# Altium schematic sheet Power section.SchDoc
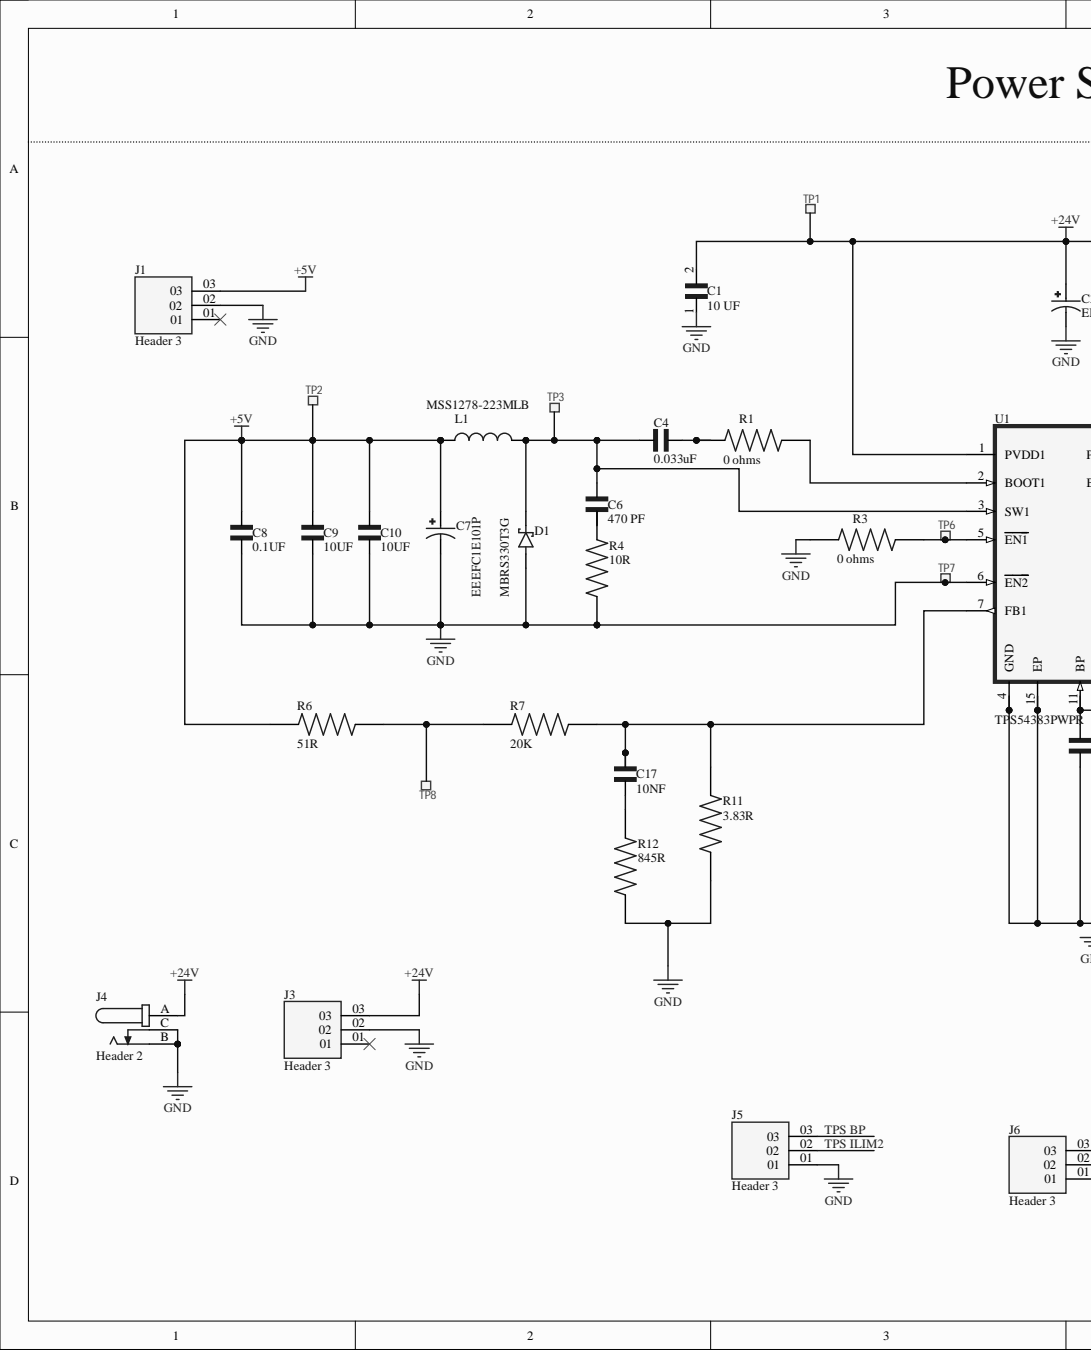
[diagram p0: Power section.SchDoc, left]
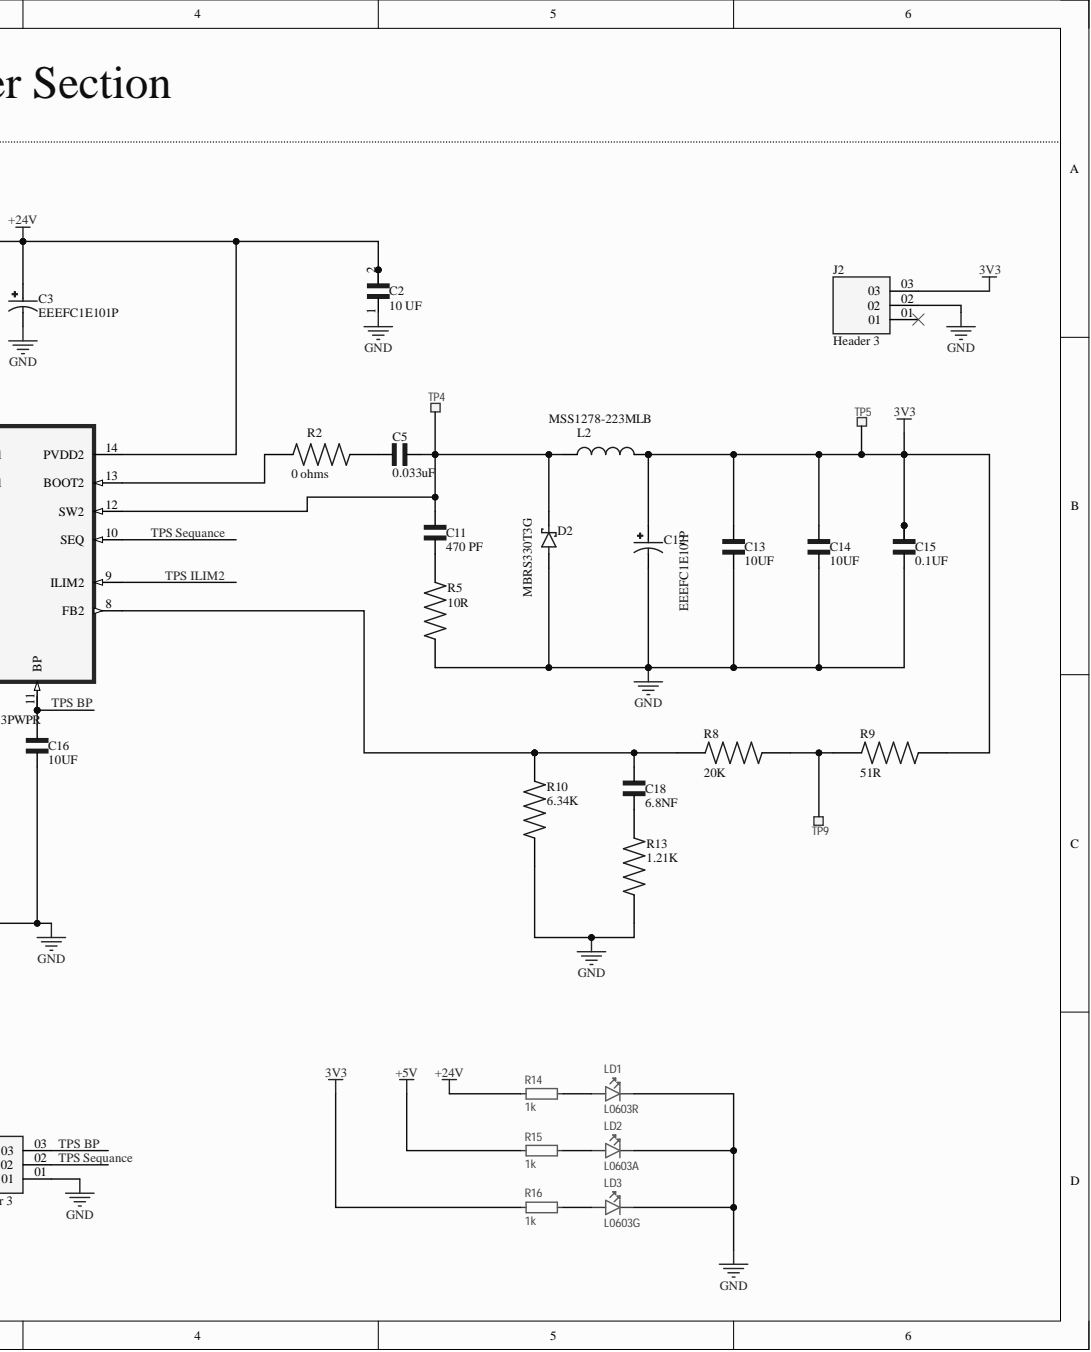
[diagram p1: Power section.SchDoc, right]

## Parts
C1  10 UF  [CAPC3216X180N]
C2  10 UF  [CAPC3216X180N]
C3  EEEFC1E101P  [CAP_EEEFC1E101P]
C4  0.033uF  [CAPC1608X90]
C5  0.033uF  [CAPC1608X90]
C6  470 PF  [CAPC1608X90]
C7  EEEFC1E101P  [CAP_EEEFC1E101P]
C8  0.1UF  [CAPC1608X90]
C9  10UF  [CAPC2012X140N]
C10  10UF  [CAPC2012X140N]
C11  470 PF  [CAPC1608X90]
C12  EEEFC1E101P  [CAP_EEEFC1E101P]
C13  10UF  [CAPC2012X140N]
C14  10UF  [CAPC2012X140N]
C15  0.1UF  [CAPC1608X90]
C16  10UF  [CAPC2012X140N]
C17  10NF  [CAPC1608X90]
C18  6.8NF  [CAPC1608X90]
D1  MBRS330T3G  [DIOM7959X261N]
D2  MBRS330T3G  [DIOM7959X261N]
J1  Header 3  [SAMTEC_HMTSW-103-XX-X-S-XXX]
J2  Header 3  [SAMTEC_HMTSW-103-XX-X-S-XXX]
J3  Header 3  [SAMTEC_HMTSW-103-XX-X-S-XXX]
J4  Header 2  [TENSILITY_54-00129]
J5  Header 3  [SAMTEC_HMTSW-103-XX-X-S-XXX]
J6  Header 3  [SAMTEC_HMTSW-103-XX-X-S-XXX]
L1  MSS1278-223MLB  [IND_MSS1278-223MLB]
L2  MSS1278-223MLB  [IND_MSS1278-223MLB]
LD1  L0603R  [LED_0603_R]
LD2  L0603A  [LED_0603_Y]
LD3  L0603G  [LED_0603_G]
R1  0 ohms  [RESC1608X55N]
R2  0 ohms  [RESC1608X55N]
R3  0 ohms  [RESC1608X55N]
R4  10R  [RESC1608X55N]
R5  10R  [RESC1608X55N]
R6  51R  [RESC1608X55N]
R7  20K  [RESC1608X55N]
R8  20K  [RESC1608X55N]
R9  51R  [RESC1608X55N]
R10  6.34K  [RESC1608X55N]
R11  3.83R  [RESC1608X55N]
R12  845R  [RESC1608X55N]
R13  1.21K  [RESC1608X55N]
R14  1k  [RESC0603X03L_C]
R15  1k  [RESC0603X03L_C]
R16  1k  [RESC0603X03L_C]
TP1  Ø0.7mm,SMD  [TP_SMD_D0.7mm]  (value not drawn)  (no BOM)
TP2  Ø0.7mm,SMD  [TP_SMD_D0.7mm]  (value not drawn)  (no BOM)
TP3  Ø0.7mm,SMD  [TP_SMD_D0.7mm]  (value not drawn)  (no BOM)
TP4  Ø0.7mm,SMD  [TP_SMD_D0.7mm]  (value not drawn)  (no BOM)
TP5  Ø0.7mm,SMD  [TP_SMD_D0.7mm]  (value not drawn)  (no BOM)
TP6  Ø0.7mm,SMD  [TP_SMD_D0.7mm]  (value not drawn)  (no BOM)
TP7  Ø0.7mm,SMD  [TP_SMD_D0.7mm]  (value not drawn)  (no BOM)
TP8  Ø0.7mm,SMD  [TP_SMD_D0.7mm]  (value not drawn)  (no BOM)
TP9  Ø0.7mm,SMD  [TP_SMD_D0.7mm]  (value not drawn)  (no BOM)
U1  TPS54383PWPR  [IC_TPS27S100BPWPR]

## Nets
3V3: C12.1 C13.1 C14.1 C15.1 J2.03 L2.2 R9.1 R16.1 TP5.1
+5V: C7.1 C8.1 C9.1 C10.1 J1.03 L1.1 R6.2 R15.1 TP2.1
+24V: C1.2 C2.2 C3.1 J3.03 J4.A R14.1 TP1.1 U1.1(PVDD1) U1.14(PVDD2)
GND: C1.1 C2.1 C3.2 C7.2 C8.2 C9.2 C10.2 C12.2 C13.2 C14.2 C15.2 C16.2 D1.A D2.A J1.02 J2.02 J3.02 J4.B J4.C J5.01 J6.01 LD1.1 LD2.1 LD3.1 R3.1 R4.1 R5.1 R10.1 R11.1 R12.1 R13.1 TP7.1 U1.4(GND) U1.6(EN2) U1.15(EP)
TPS BP: C16.1 J5.03 J6.03 U1.11(BP)
TPS ILIM2: J5.02 U1.9(ILIM2)
TPS Sequance: J6.02 U1.10(SEQ)
NetC4_1: C4.1 R1.1
NetC4_2: C4.2 C6.1 D1.C L1.2 TP3.1 U1.3(SW1)
NetC5_1: C5.1 C11.1 D2.C L2.1 TP4.1 U1.12(SW2)
NetC5_2: C5.2 R2.2
NetC6_2: C6.2 R4.2
NetC11_2: C11.2 R5.2
NetC17_1: C17.1 R7.1 R11.2 U1.7(FB1)
NetC17_2: C17.2 R12.2
NetC18_1: C18.1 R8.2 R10.2 U1.8(FB2)
NetC18_2: C18.2 R13.2
NetLD1_2: LD1.2 R14.2
NetLD2_2: LD2.2 R15.2
NetLD3_2: LD3.2 R16.2
NetR1_2: R1.2 U1.2(BOOT1)
NetR2_1: R2.1 U1.13(BOOT2)
NetR3_2: R3.2 TP6.1 U1.5(EN1)
NetR6_1: R6.1 R7.2 TP8.1
NetR8_1: R8.1 R9.2 TP9.1

Not connected: J1 01; J2 01; J3 01

## Library data of the parts
C1 C2 = C3216X5R1E106M160AB: 10µF Â±20% 25V Ceramic Capacitor 1206 (3216 Metric); MF: TDK; Check_prices: https://www.snapeda.com/parts/C3216X5R1E106M160AB/TDK/view-part/?ref=eda; Component Kind: Standard; Digi-Key_Part_Number: 445-7708-2-ND; Library Name: C3216X5R1E106M160AB.IntLib; MP: C3216X5R1E106M160AB; Package: 3216 TDK; Purchase-URL: https://pricing.snapeda.com/search/part/C3216X5R1E106M160AB/?ref=eda; SnapEDA_Link: https://www.snapeda.com/parts/C3216X5R1E106M160AB/TDK/view-part/?ref=snap
C3 C7 C12 = EEEFC1E101P: Capacitor,Alum Electrolytic,Cap 100 uF,Tol 20%,Vol-Rtg 25 VDC,SMT,8x10.2,TR | Panasonic Electronic Components EEE-FC1E101P; Check_prices: https://www.snapeda.com/parts/EEE-FC1E101P/Panasonic+Electronic+Components/view-part/?ref=eda; Component Kind: Standard; Library Name: EEEFC1E101P.IntLib; MF: Panasonic; MP: EEE-FC1E101P; Package: RADIAL-2 Panasonic; SnapEDA_Link: https://www.snapeda.com/parts/EEE-FC1E101P/Panasonic+Electronic+Components/view-part/?ref=snap
C4 C5 = C0603C104J3RACTU: Capacitor, ceramic, 25 V, X7R, 20%, .033 μF, 0603; MF: KEMET; Check_prices: https://www.snapeda.com/parts/C0603C104J3RACTU/KEMET/view-part/?ref=eda; Component Kind: Standard; MP: C0603C104J3RACTU; Package: 603-2 KEMET; SnapEDA_Link: https://www.snapeda.com/parts/C0603C104J3RACTU/KEMET/view-part/?ref=snap; Library Name: Accelo Integrated_Library.IntLib
C6 C11 = C0603C104J3RACTU: Capacitor, ceramic, 25 V, X7R, 20%, 470 pF, 0603; MF: KEMET; Check_prices: https://www.snapeda.com/parts/C0603C104J3RACTU/KEMET/view-part/?ref=eda; Component Kind: Standard; MP: C0603C104J3RACTU; Package: 603-2 KEMET; SnapEDA_Link: https://www.snapeda.com/parts/C0603C104J3RACTU/KEMET/view-part/?ref=snap; Library Name: Accelo Integrated_Library.IntLib
C8 C15 = C0603C104J3RACTU: Capacitor, ceramic, 10 V, X7R, 20%, 0.1 μF, 0603; MF: KEMET; Check_prices: https://www.snapeda.com/parts/C0603C104J3RACTU/KEMET/view-part/?ref=eda; Component Kind: Standard; MP: C0603C104J3RACTU; Package: 603-2 KEMET; SnapEDA_Link: https://www.snapeda.com/parts/C0603C104J3RACTU/KEMET/view-part/?ref=snap; Library Name: Accelo Integrated_Library.IntLib
C9 C10 C13 C14 = 0805ZD474MAT2A: Capacitor, ceramic, 6.3 V, X5R, 20%, 10 μF, 0805; MF: AVX; Check_prices: https://www.snapeda.com/parts/0805ZD474MAT2A/AVX/view-part/?ref=eda; Component Kind: Standard; Library Name: 0805ZD474MAT2A.IntLib; MP: 0805ZD474MAT2A; Package: 0805-2 AVX; SnapEDA_Link: https://www.snapeda.com/parts/0805ZD474MAT2A/AVX/view-part/?ref=snap
C16 = 0805ZD474MAT2A: 0.47 ÂµF Â±20% 10V Ceramic Capacitor X5R 0805 (2012 Metric); MF: AVX; Check_prices: https://www.snapeda.com/parts/0805ZD474MAT2A/AVX/view-part/?ref=eda; Component Kind: Standard; Library Name: 0805ZD474MAT2A.IntLib; MP: 0805ZD474MAT2A; Package: 0805-2 AVX; SnapEDA_Link: https://www.snapeda.com/parts/0805ZD474MAT2A/AVX/view-part/?ref=snap
C17 C18 = C0603C104J3RACTU: 0603 C 100nF Ceramic Multilayer Capacitor, 25 V, +125degC, X7R Dielectric, +/-5% | KEMET C0603C104J3RACTU; Check_prices: https://www.snapeda.com/parts/C0603C104J3RACTU/KEMET/view-part/?ref=eda; Component Kind: Standard; MF: KEMET; MP: C0603C104J3RACTU; Package: 603-2 KEMET; SnapEDA_Link: https://www.snapeda.com/parts/C0603C104J3RACTU/KEMET/view-part/?ref=snap; Library Name: Accelo Integrated_Library.IntLib
D1 D2 = MBRS330T3G: Diode Schottky 30 V 3A Surface Mount SMC; MF: ON Semiconductor; Check_prices: https://www.snapeda.com/parts/MBRS330T3G/Onsemi/view-part/?ref=eda; Component Kind: Standard; Library Name: MBRS330T3G.IntLib; MP: MBRS330T3G; Package: SMC-2 ON Semiconductor; SnapEDA_Link: https://www.snapeda.com/parts/MBRS330T3G/Onsemi/view-part/?ref=snap
J1-J3 J5 J6 = HMTSW-103-XX-X-S-XXX: Connector Unshrouded Header 3 Position 2.54mm Solder Straight Through Hole; MF: Samtec; MP: HMTSW-103-10-T-S-330; Check_prices: https://www.snapeda.com/parts/HMTSW-103-10-T-S-330/Samtec/view-part/?ref=eda; Component Kind: Standard; Package: None; SnapEDA_Link: https://www.snapeda.com/parts/HMTSW-103-10-T-S-330/Samtec/view-part/?ref=snap; Library Name: Accelo Integrated_Library.IntLib
J4 = 54-00129: Connector, dc jack 5.5x2.1 mm, PCB mount, 90 degrees, silver plated, thru hole; MF: Tensility International Corp; Availability: In Stock; Check_prices: https://www.snapeda.com/parts/54-00129/Tensility+International+Corp/view-part/?ref=eda; Component Kind: Standard; Library Name: 54-00129.IntLib; MP: 54-00129; Package: None; Price: None; Purchase-URL: https://pricing.snapeda.com/search?q=54-00129&ref=eda; SnapEDA_Link: https://www.snapeda.com/parts/54-00129/Tensility+International+Corp/view-part/?ref=snap
L1 L2 = MSS1278-223MLB: Fixed Inductors 22uH Shld 20% 4A 39.5mOhms AECQ2; MF: Coilcraft; Check_prices: https://www.snapeda.com/parts/MSS1278-223MLB/Coilcraft/view-part/?ref=eda; Component Kind: Standard; Library Name: MSS1278-223MLB.IntLib; MP: MSS1278-223MLB; Package: None; SnapEDA_Link: https://www.snapeda.com/parts/MSS1278-223MLB/Coilcraft/view-part/?ref=snap
LD1 = ASMT-RR45-AQ902: LED, Red, 0603, Vf=2V, - 40 to +100°C; Manufacturer: Avago; Part number NEMS: 101400008; Artikkelnr Noca: N.A.; Component Status: Active; Note: 90 mcd @ 20 mA; Pad Count: 2; Component Class: Optoelectronics; Component Kind: Standard; ComponentLink1Description: Datasheet; ComponentLink1URL: N.A.; ComponentLink2Description: Supplier link; ComponentLink2URL: N.A.; Footprint Doc: 0603; HelpURL: http://www.avagotech.com/docs/AV02-0378EN; LatestRevisionDate: 2015-06-12; Library Name: pca10152.IntLib; Manufacturer Part Number: ASMT-RR45-AQ902; Part Number: ASMT-RR45-AQ902; Part number IPS: N.A.; Part number Norautron: N.A.; PartId: 101400008; Published: 2015-06-12; Supplier 1: Digi-Key; Supplier 2: Mouser; Supplier Part Number 1: 516-2005-1-ND; Supplier Part Number 2: 630-ASMT-RR45-AQ902; Value: L0603R; Author: rsk
LD2 = ASMT-RA45-AP932: LED, Amber, 0603,  Vf=2V, - 40 to +100°C; Manufacturer: Avago; Part number NEMS: 101400011; Artikkelnr Noca: N.A.; Component Status: Active; Note: 57 mcd @ 20 mA; Pad Count: 2; Component Class: Optoelectronics; Component Kind: Standard; ComponentLink1Description: Datasheet; ComponentLink1URL: N.A.; ComponentLink2Description: Supplier link; ComponentLink2URL: N.A.; Footprint Doc: 0603; HelpURL: http://www.avagotech.com/docs/AV02-0378EN; LatestRevisionDate: 2015-06-12; Library Name: pca10152.IntLib; Manufacturer Part Number: ASMT-RA45-AP932; Part Number: ASMT-RA45-AP932; Part number IPS: N.A.; Part number Norautron: N.A.; PartId: 101400011; Published: 2015-06-12; Supplier 1: N.A.; Supplier 2: Mouser; Supplier Part Number 1: N.A.; Supplier Part Number 2: 630-ASMT-RA45-AP932; Value: L0603A; Author: rsk
LD3 = ASMT-RF45-AN002: LED, Yellow Green, 0603, Vf=2V, - 40 to +100°C; Manufacturer: Avago; Part number NEMS: 101400010; Artikkelnr Noca: N.A.; Component Status: Active; Note: 28 mcd @ 20 mA; Pad Count: 2; Component Class: Optoelectronics; Component Kind: Standard; ComponentLink1Description: Datasheet; ComponentLink1URL: N.A.; ComponentLink2Description: Supplier link; ComponentLink2URL: N.A.; Footprint Doc: 0603; HelpURL: http://www.avagotech.com/docs/AV02-0378EN; LatestRevisionDate: 2015-06-12; Library Name: pca10152.IntLib; Manufacturer Part Number: ASMT-RF45-AN002; Part Number: ASMT-RF45-AN002; Part number IPS: N.A.; Part number Norautron: N.A.; PartId: 101400010; Published: 2015-06-12; Supplier 1: Digi-Key; Supplier 2: Mouser; Supplier Part Number 1: 516-2522-1-ND; Supplier Part Number 2: 630-ASMT-RF45-AN002; Value: L0603G; Author: rsk
R1-R3 = RC0603JR-0751RL: Resistor, chip, 1/16 W, 5%, 0 Ω, 0603; MF: Yageo; Check_prices: https://www.snapeda.com/parts/RC0603JR-0751RL/Yageo/view-part/?ref=eda; Component Kind: Standard; Library Name: RC0603JR-0751RL.IntLib; MP: RC0603JR-0751RL; Package: 1608 Yageo; SnapEDA_Link: https://www.snapeda.com/parts/RC0603JR-0751RL/Yageo/view-part/?ref=snap
R4 R5 = RC0603JR-0751RL: Resistor, chip, 1/16 W, 5%, 10 Ω, 0603; MF: Yageo; Check_prices: https://www.snapeda.com/parts/RC0603JR-0751RL/Yageo/view-part/?ref=eda; Component Kind: Standard; Library Name: RC0603JR-0751RL.IntLib; MP: RC0603JR-0751RL; Package: 1608 Yageo; SnapEDA_Link: https://www.snapeda.com/parts/RC0603JR-0751RL/Yageo/view-part/?ref=snap
R6-R13 = RC0603JR-0751RL: 51 Ohms Â±5% 0.1W, 1/10W Chip Resistor 0603 (1608 Metric) Moisture Resistant Thick Film; MF: Yageo; Check_prices: https://www.snapeda.com/parts/RC0603JR-0751RL/Yageo/view-part/?ref=eda; Component Kind: Standard; Library Name: RC0603JR-0751RL.IntLib; MP: RC0603JR-0751RL; Package: 1608 Yageo; SnapEDA_Link: https://www.snapeda.com/parts/RC0603JR-0751RL/Yageo/view-part/?ref=snap
R14-R16 = R0201-1k0: Resistor, ±1%, 0.05W; Part number IPS: 00000005786; Part number NEMS: 100100043; Artikkelnr Noca: N.A.; Component Status: Active; Note: Chip Res 1%; Pad Count: 2; Component Class: Resistors; Component Kind: Standard; ComponentLink1Description: Datasheet; ComponentLink1URL: N.A.; ComponentLink2Description: Supplier link; ComponentLink2URL: N.A.; Footprint Doc: 0201; HelpURL: N.A.; LatestRevisionDate: 2014-11-13; Library Name: pca10152.IntLib; Manufacturer: N.A.; Manufacturer Part Number: N.A.; Part Number: R0201-1k0; Part number Norautron: N.A.; PartId: 100100043; Published: 2014-11-13; Supplier 1: N.A.; Supplier 2: N.A.; Supplier Part Number 1: N.A.; Supplier Part Number 2: N.A.; Value: 1k; Author: rsk
TP1-TP9 = TP_SMD_Ø0.7MM: 0.7mm circular SMD testpad; Manufacturer: Nordic Semiconductor; Part number NEMS: 100500474; Artikkelnr Noca: N.A.; Component Status: Active; Pad Count: 1; System: Connector; Component Class: Connectors; ComponentLink1Description: Datasheet; ComponentLink1URL: N.A.; ComponentLink2Description: Supplier link; ComponentLink2URL: N.A.; Footprint Doc: TP_SMD_Ø0.7mm; HelpURL: N.A.; LatestRevisionDate: 2021-03-05; Library Name: pca10152.IntLib; Manufacturer Part Number: N.A.; Note: N.A.; Part Number: TP_SMD_Ø0.7MM; Part number IPS: N.A.; Part number Norautron: N.A.; PartId: 100500474; Published: 2017-12-14; Supplier 1: N.A.; Supplier 2: N.A.; Supplier Part Number 1: N.A.; Supplier Part Number 2: N.A.; Value: Ø0.7mm,SMD; Author: rsk
U1 = TPS54383PWPR: MF: {'manufacturer': u'Texas Instruments'}; Availability: Unavailable; Component Kind: Standard; Library Name: TP.IntLib; MP: TPS54383PWPR; Package: None; Price: None
